# Altium schematic sheet IR_REC.SchDoc
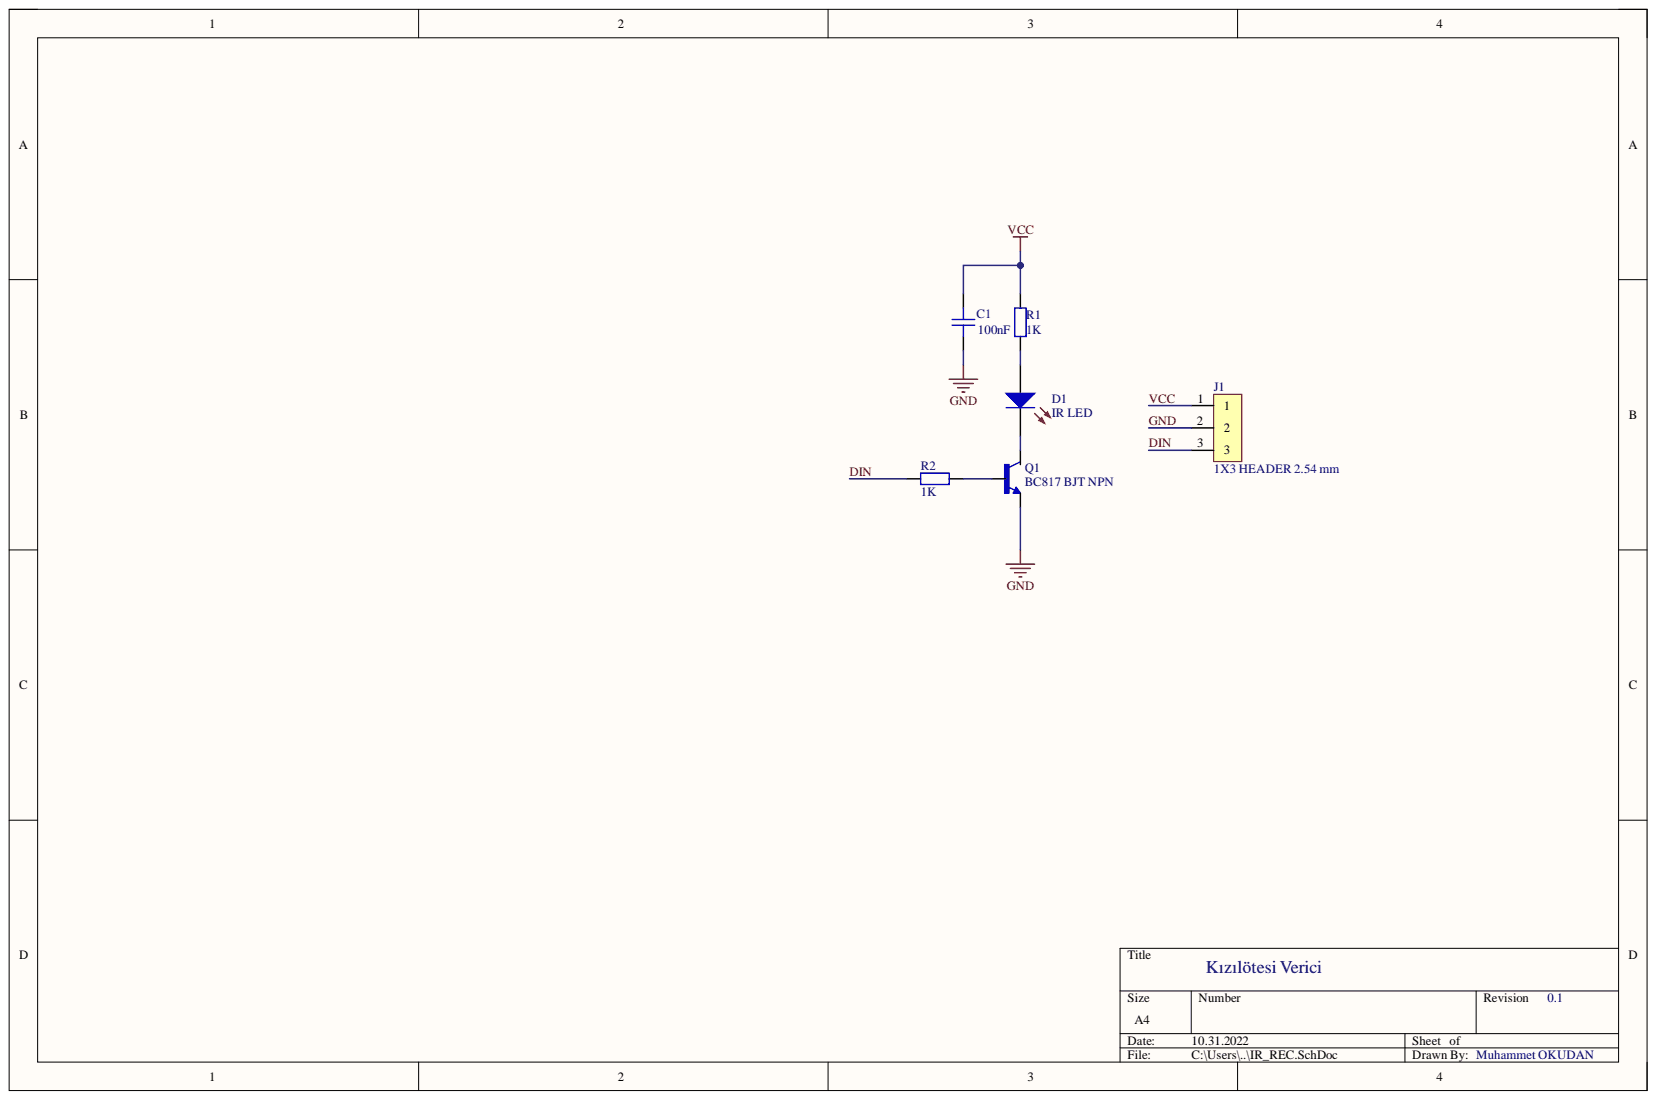
[diagram p0: IR_REC.SchDoc]

## Parts
C1  100nF  [0603 CAP]
D1  IR LED  [IR LED]
J1  1X3 HEADER 2.54 mm  [1X3 HEADER]
Q1  BC817 BJT NPN  [BC817]
R1  1K  [0603 RES]
R2  1K  [0603 RES]

## Nets
DIN: J1.3 R2.1
GND: C1.1 J1.2 Q1.2
VCC: C1.2 J1.1 R1.2
NetD1_1: D1.1 R1.1
NetD1_2: D1.2 Q1.3
NetQ1_1: Q1.1 R2.2

## Library data of the parts
C1 = 0603 cap: Component Kind: Standard; Library Name: IR_REC.SchLib
R1 R2 = 0603 RES: Component Kind: Standard; Library Name: IR_REC.SchLib
D1 = IR LED: Component Kind: Standard; Library Name: IR_REC.SchLib
J1 = 1X3 HEADER 2.54 mm: Component Kind: Standard; Library Name: IR_REC.SchLib
Q1 = BC817 BJT NPN: Component Kind: Standard; Library Name: IR_REC.SchLib
